# Altium schematic sheet MCU.SchDoc
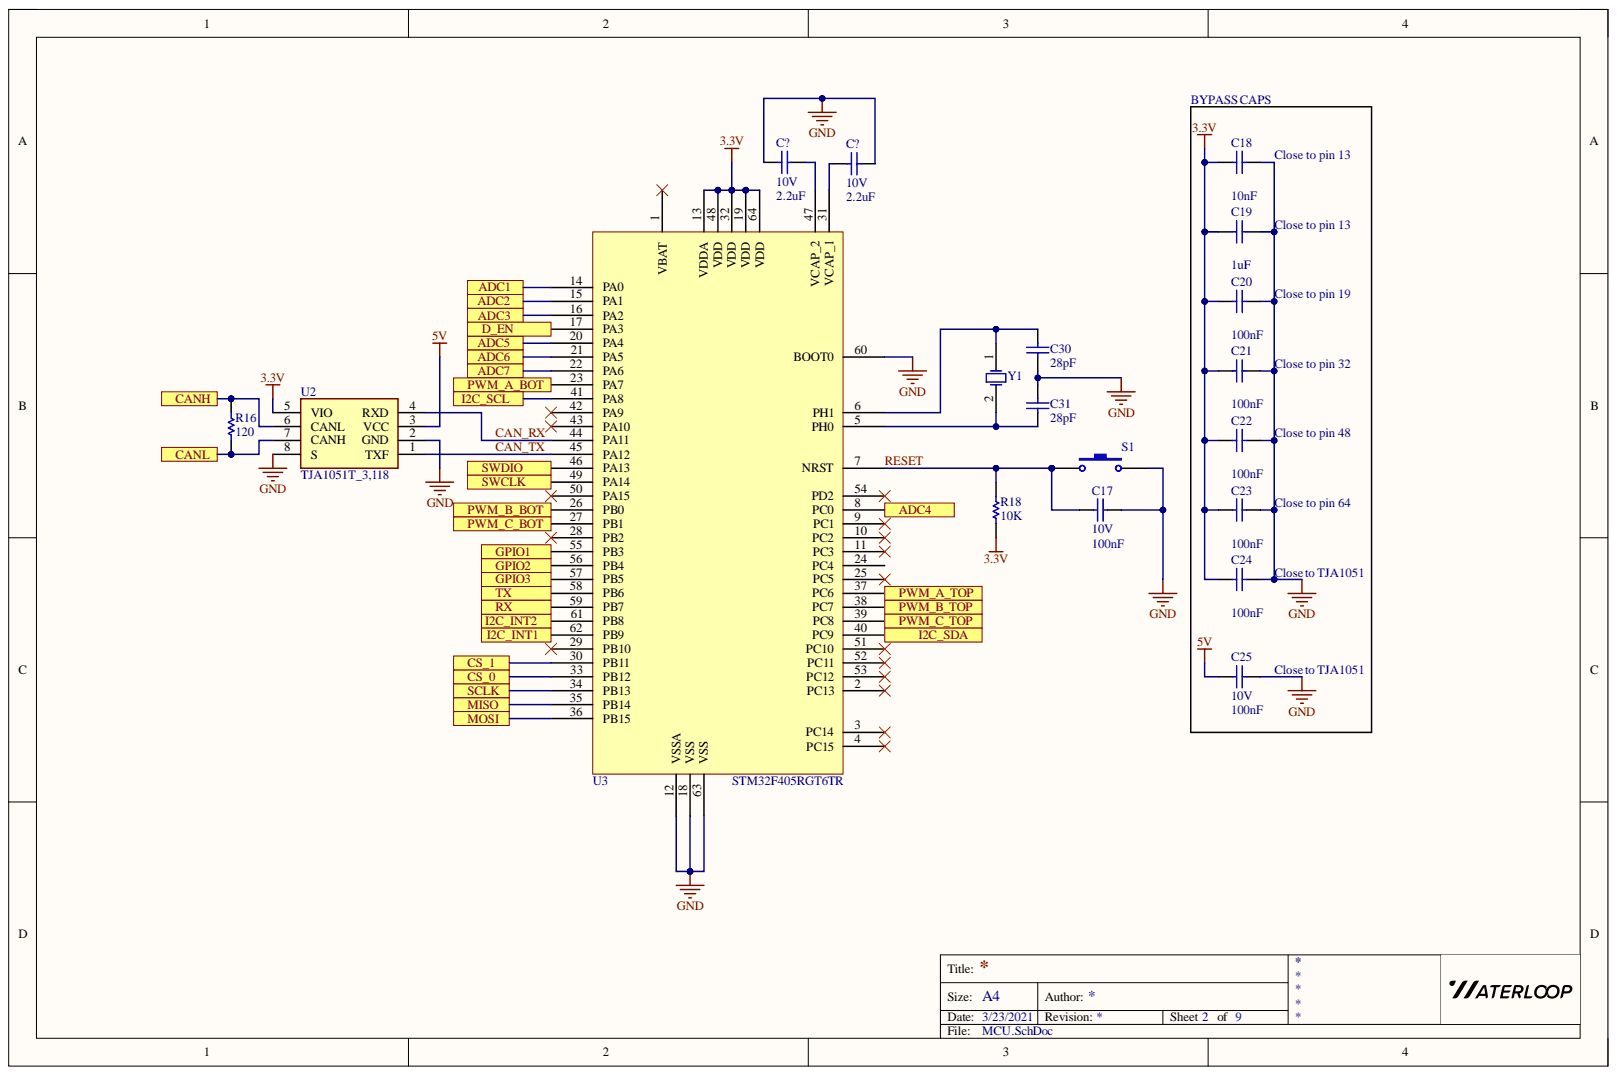
[diagram p0: MCU.SchDoc]

## Parts
C17  10V  value 100nF  [C0805]
C18  value 10nF  [C0805]
C19  value 1uF  [C0805]
C20  (no value)  [C0805]
C21  value 100nF  [C0805]
C22  value 100nF  [C0805]
C23  value 100nF  [C0805]
C24  value 100nF  [C0805]
C25  10V  value 100nF  [C0805]
C30  value 28pF  [C0805]  (hidden comment: 50V)
C31  value 28pF  [C0805]  (hidden comment: 50V)
C?  10V  [C0805]
C?  10V  [C0805]
R16  value 120  [J1-0603]  (hidden comment: 5%)
R18  value 10K  [J1-0603]  (hidden comment: 5%)
S1  SW-PB  [PTS636 SM43 SMTR LFS]  (value not drawn)
U2  TJA1051T_3,118  [SOIC127P600X175-8N]
U3  STM32F405RGT6TR  [STM32F405RGT6TR]
Y1  CX5032GB08000H0HPQZ1  [CX5032GB08000H0HPQZ1]  (value not drawn)

## Ports
ADC1, ADC2, ADC3, ADC4, ADC5, ADC6, ADC7, CANH, CANL, CS_0, CS_1, D_EN, GPIO1, GPIO2, GPIO3, I2C_INT1, I2C_INT2, I2C_SCL, I2C_SDA, MISO, MOSI, PWM_A_BOT, PWM_A_TOP, PWM_B_BOT, PWM_B_TOP, PWM_C_BOT, PWM_C_TOP, RX, SCLK, SWCLK, SWDIO, TX

## Nets
3.3V: C18.1 C19.2 C20.1 C21.1 C22.1 C23.1 C24.1 R18.1 U2.5(VIO) U3.13(VDDA) U3.19(VDD) U3.32(VDD) U3.48(VDD) U3.64(VDD)
5V: C25.1 U2.3(VCC)
GND: C17.2 C18.2 C19.1 C20.2 C21.2 C22.2 C23.2 C24.2 C25.2 C30.2 C31.1 C?.1 C?.2 S1.2 U2.2(GND) U2.8(S) U3.12(VSSA) U3.18(VSS) U3.60(BOOT0) U3.63(VSS)
ADC1: U3.14(PA0) ; port ADC1
ADC2: U3.15(PA1) ; port ADC2
ADC3: U3.16(PA2) ; port ADC3
ADC4: U3.8(PC0) ; port ADC4
ADC5: U3.20(PA4) ; port ADC5
ADC6: U3.21(PA5) ; port ADC6
ADC7: U3.22(PA6) ; port ADC7
CAN_RX: U2.4(RXD) U3.44(PA11)
CAN_TX: U2.1(TXF) U3.45(PA12)
CANH: R16.2 U2.6(CANL) ; port CANH
CANL: R16.1 U2.7(CANH) ; port CANL
CS_0: U3.33(PB12) ; port CS_0
CS_1: U3.30(PB11) ; port CS_1
D_EN: U3.17(PA3) ; port D_EN
GPIO1: U3.55(PB3) ; port GPIO1
GPIO2: U3.56(PB4) ; port GPIO2
GPIO3: U3.57(PB5) ; port GPIO3
I2C_INT1: U3.62(PB9) ; port I2C_INT1
I2C_INT2: U3.61(PB8) ; port I2C_INT2
I2C_SCL: U3.41(PA8) ; port I2C_SCL
I2C_SDA: U3.40(PC9) ; port I2C_SDA
MISO: U3.35(PB14) ; port MISO
MOSI: U3.36(PB15) ; port MOSI
PWM_A_BOT: U3.23(PA7) ; port PWM_A_BOT
PWM_A_TOP: U3.37(PC6) ; port PWM_A_TOP
PWM_B_BOT: U3.26(PB0) ; port PWM_B_BOT
PWM_B_TOP: U3.38(PC7) ; port PWM_B_TOP
PWM_C_BOT: U3.27(PB1) ; port PWM_C_BOT
PWM_C_TOP: U3.39(PC8) ; port PWM_C_TOP
RESET: C17.1 R18.2 S1.1 U3.7(NRST)
RX: U3.59(PB7) ; port RX
SCLK: U3.34(PB13) ; port SCLK
SWCLK: U3.49(PA14) ; port SWCLK
SWDIO: U3.46(PA13) ; port SWDIO
TX: U3.58(PB6) ; port TX
NetC30_1: C30.1 U3.6(PH1) Y1.1
NetC31_2: C31.2 U3.5(PH0) Y1.2
NetC?_1: C?.1 U3.47(VCAP_2)
NetC?_2: C?.2 U3.31(VCAP_1)

Not connected: U3 1(VBAT) 2(PC13) 3(PC14) 4(PC15) 9(PC1) 10(PC2) 11(PC3) 24(PC4) 25(PC5) 28(PB2) 29(PB10) 42(PA9) 43(PA10) 50(PA15) 51(PC10) 52(PC11) 53(PC12) 54(PD2)

## Library data of the parts
C17 C21-C25 = Cap: C0805C104K8RACTU. 0.1µF ±10% 10V Ceramic Capacitor X7R 0805 (2012 Metric); Component Kind: Standard; LatestRevisionDate: 17-Jul-2002; LatestRevisionNote: Re-released for DXP Platform.; Library Name: Miscellaneous Devices.IntLib; PackageDescription: Radial Cap, Thru-Hole; 2 Leads; 0.3 in Pin Spacing; PackageReference: RAD-0.3; Published: 8-Jun-2000; Signal Integrity: Cap; Simulation: CAP; Publisher: Altium Limited
C18 = Cap: C0805C103K8RAC7800. 10000pF ±10% 10V Ceramic Capacitor X7R 0805 (2012 Metric); Value: 10nF; Component Kind: Standard; LatestRevisionDate: 17-Jul-2002; LatestRevisionNote: Re-released for DXP Platform.; Library Name: Miscellaneous Devices.IntLib; PackageDescription: Radial Cap, Thru-Hole; 2 Leads; 0.3 in Pin Spacing; PackageReference: RAD-0.3; Published: 8-Jun-2000; Signal Integrity: Cap; Simulation: CAP; Publisher: Altium Limited
C19 = Cap: CL21B105KPFNNNE. 1µF ±10% 10V Ceramic Capacitor X7R 0805 (2012 Metric); Value: 1uF; Component Kind: Standard; LatestRevisionDate: 17-Jul-2002; LatestRevisionNote: Re-released for DXP Platform.; Library Name: Miscellaneous Devices.IntLib; PackageDescription: Radial Cap, Thru-Hole; 2 Leads; 0.3 in Pin Spacing; PackageReference: RAD-0.3; Published: 8-Jun-2000; Signal Integrity: Cap; Simulation: CAP; Publisher: Altium Limited
C20 = Cap: C0805C104K8RACTU. 0.1µF ±10% 10V Ceramic Capacitor X7R 0805 (2012 Metric); Value: 100nF; Component Kind: Standard; LatestRevisionDate: 17-Jul-2002; LatestRevisionNote: Re-released for DXP Platform.; Library Name: Miscellaneous Devices.IntLib; PackageDescription: Radial Cap, Thru-Hole; 2 Leads; 0.3 in Pin Spacing; PackageReference: RAD-0.3; Published: 8-Jun-2000; Signal Integrity: Cap; Simulation: CAP; Publisher: Altium Limited
C30 = Cap: CBR08C409B5GAC; Component Kind: Standard; LatestRevisionDate: 17-Jul-2002; LatestRevisionNote: Re-released for DXP Platform.; Library Name: Miscellaneous Devices.IntLib; PackageDescription: Radial Cap, Thru-Hole; 2 Leads; 0.3 in Pin Spacing; PackageReference: RAD-0.3; Published: 8-Jun-2000; Signal Integrity: Cap; Simulation: CAP; Publisher: Altium Limited
C31 = Cap: CBR08C409B5GAC. 4pF ±0.1pF 50V Ceramic Capacitor C0G, NP0 0805 (2012 Metric); Component Kind: Standard; LatestRevisionDate: 17-Jul-2002; LatestRevisionNote: Re-released for DXP Platform.; Library Name: Miscellaneous Devices.IntLib; PackageDescription: Radial Cap, Thru-Hole; 2 Leads; 0.3 in Pin Spacing; PackageReference: RAD-0.3; Published: 8-Jun-2000; Signal Integrity: Cap; Simulation: CAP; Publisher: Altium Limited
C? C? = Cap: C0805C225K8RACAUTO. 2.2µF ±10% 10V Ceramic Capacitor X7R 0805 (2012 Metric); Value: 2.2uF; Component Kind: Standard; LatestRevisionDate: 17-Jul-2002; LatestRevisionNote: Re-released for DXP Platform.; Library Name: Miscellaneous Devices.IntLib; PackageDescription: Radial Cap, Thru-Hole; 2 Leads; 0.3 in Pin Spacing; PackageReference: RAD-0.3; Published: 8-Jun-2000; Signal Integrity: Cap; Simulation: CAP; Publisher: Altium Limited
R16 R18 = Res1: ERJ-3GEYJ103V. 10 kOhms ±5% 0.1W, 1/10W Chip Resistor 0603 (1608 Metric) Automotive AEC-Q200 Thick Film; Component Kind: Standard; LatestRevisionDate: 17-Jul-2002; LatestRevisionNote: Re-released for DXP Platform.; Library Name: Miscellaneous Devices.IntLib; PackageDescription: Axial Device, Thru-Hole; 2 Leads; 0.3 in Pin Spacing; PackageReference: AXIAL-0.3; Published: 8-Jun-2000; Signal Integrity: Res; Simulation: RESISTOR; Publisher: Altium Limited
S1 = SW-PB: Switch; Component Kind: Standard; LatestRevisionDate: 17-Jul-2002; LatestRevisionNote: Re-released for DXP Platform.; Library Name: Miscellaneous Devices.IntLib; PackageDescription: Switch; 2 Leads; PackageReference: SPST-2; Published: 24-Mar-1999; Publisher: Altium Limited
U2 = TJA1051T_3,118: Integrated Circuit; Manufacturer_Name: NXP; Manufacturer_Part_Number: TJA1051T/3,118; Arrow Part Number: TJA1051T/3,118; Arrow Price/Stock: https://www.arrow.com/en/products/tja1051t3118/nxp-semiconductors; Component Kind: Standard; Datasheet Link: http://www.nxp.com/docs/en/data-sheet/TJA1051.pdf; Height: 1.75mm; Library Name: Motor Controller.SchLib; Mouser Part Number: 771-TJA1051T3118; Mouser Price/Stock: https://www.mouser.co.uk/ProductDetail/NXP-Semiconductors/TJA1051T-3118?qs=O%2F7ihdSJpebv1PFlp05wnQ%3D%3D
Y1 = XTAL: 8MHz ±20ppm Crystal 12pF 300 Ohms 2-SMD, No Lead; Component Kind: Standard; LatestRevisionDate: 17-Jul-2002; LatestRevisionNote: Re-released for DXP Platform.; Library Name: Miscellaneous Devices.IntLib; PackageDescription: Crystal, Thru-Hole; 2 Leads; Body 3.1 x 8.2 mm (Dia.xH); PackageReference: R38; Published: 8-Jun-2000; Simulation: XTAL; Publisher: Altium Limited
U3 = STM32F405RGT6TR: Component Kind: Standard; Library Name: Motor Controller.SchLib
